# Altium schematic sheet lv_signal_air.SchDoc(lv_signal_air)
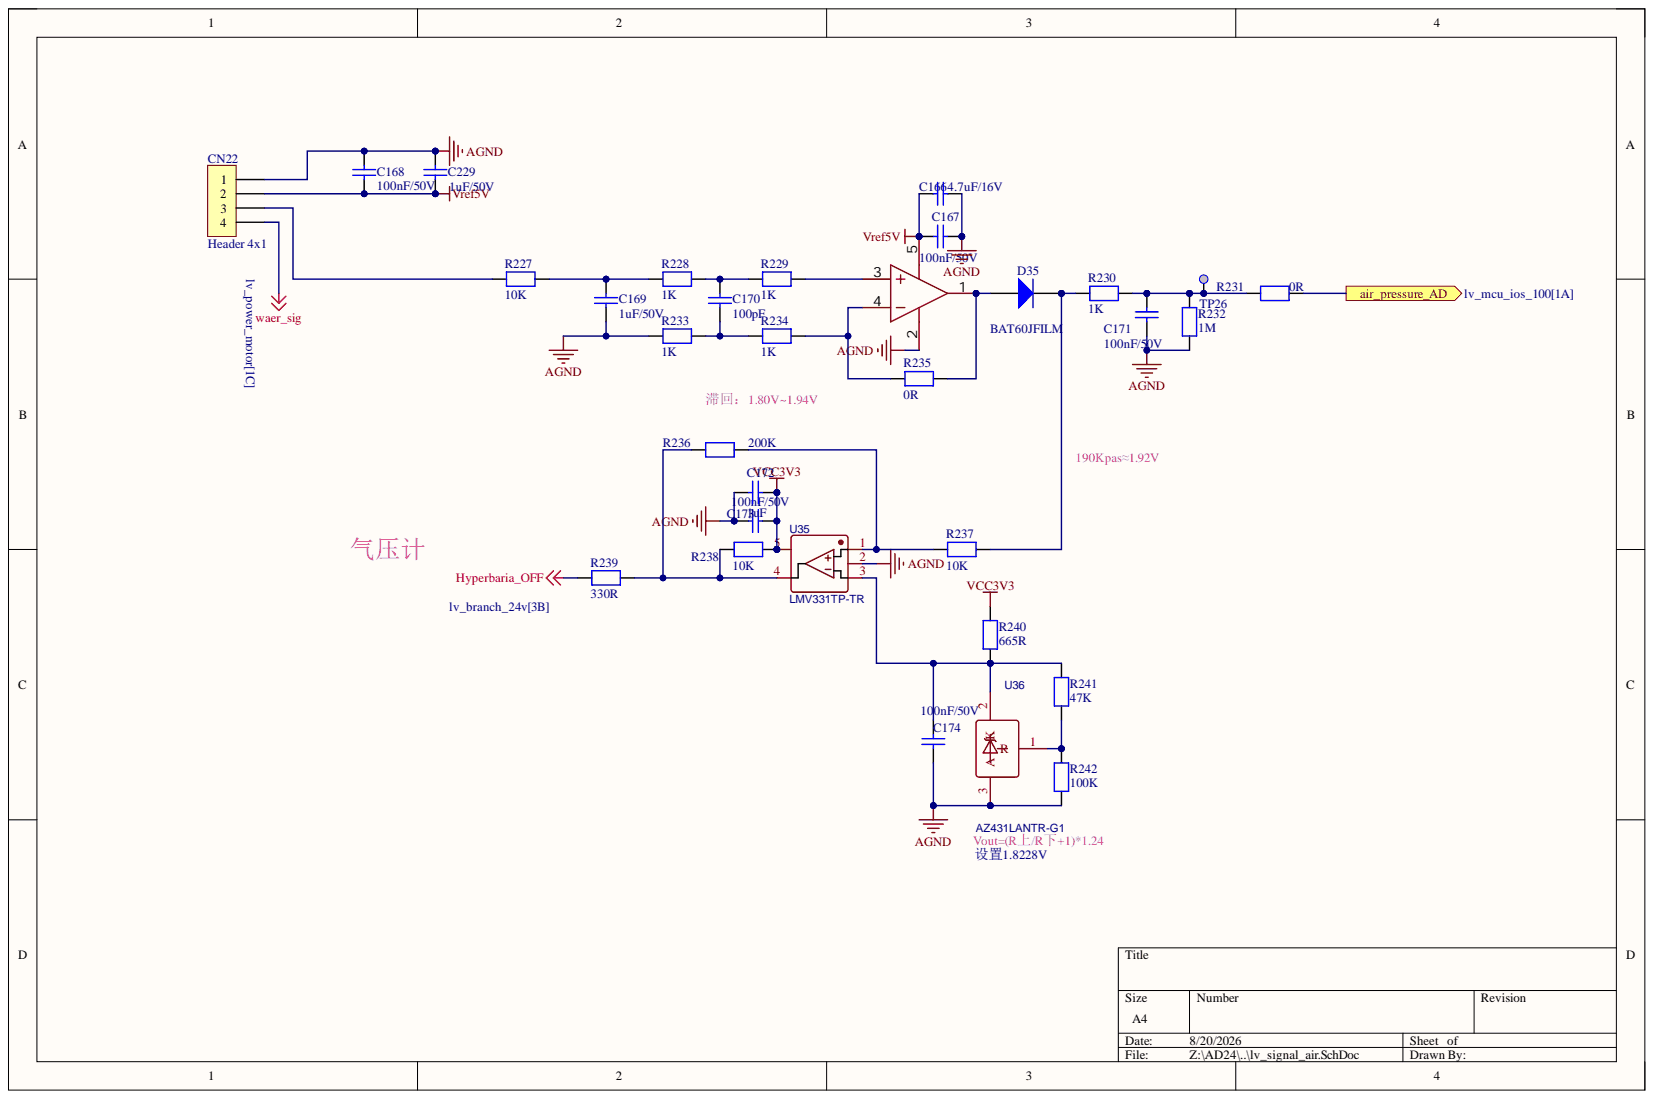
[diagram p0: lv_signal_air.SchDoc]

## Parts
C166  4.7uF/16V  [C0603]
C167  100nF/50V  [C0402]
C168  100nF/50V  [C0402]
C169  1uF/50V  [C0603]
C170  100pF  [C0402]
C171  100nF/50V  [C0402]
C172  value 1uF  [C0603]  (hidden comment: 1uF/50V)
C173  100nF/50V  [C0402]
C174  100nF/50V  [C0402]
C229  1uF/50V  [C0603]
CN22  Header 4x1  [CON_SAMTEC_TSW-104-07-G-S]
D35  BAT60JFILM  [DIO_SOD323]
R227  10K  [R0402]
R228  1K  [R0402]
R229  1K  [R0402]
R230  1K  [R0402]
R231  0R  [R0402]
R232  1M  [R0402]
R233  1K  [R0402]
R234  1K  [R0402]
R235  0R  [R0402]
R236  200K  [R0402]
R237  10K  [R0402]
R238  10K  [R0402]
R239  330R  [R0402]
R240  665R  [R0402]
R241  47K  [R0402]
R242  100K  [R0402]
TP26  AIRADC  [TP_SMD_1MM]  (value not drawn)  (no BOM)
U33  TLV271CDBVR  [SOT-23-5_L3.0-W1.7-P0.95-LS2.8-BR]  (value not drawn)
U35  LMV331TP-TR  [SOT-23-5_L3.0-W1.7-P0.95-LS2.8-BR]
U36  AZ431LANTR-G1  [SOT-23-3_L2.9-W1.6-P1.90-LS2.8-BR]

## Ports
air_pressure_AD

## Nets
AGND: C166.1 C167.2 C168.1 C169.2 C171.1 C172.1 C173.2 C174.2 C229.2 CN22.1 R232.1 R233.1 R242.1 U33.2 U35.2 U36.3
Hyperbaria_OFF: R239.1
VCC3V3: C172.2 C173.1 R238.2 R240.2 U35.5
Vref5V: C166.2 C167.1 C168.2 C229.1 CN22.2 U33.5
waer_sig: CN22.4
air_pressure_AD: R231.1 ; port air_pressure_AD
NetC169_1: C169.1 R227.2 R228.1
NetC170_1: C170.1 R228.2 R229.1
NetC170_2: C170.2 R233.2 R234.1
NetC171_2: C171.2 R230.2 R231.2 R232.2 TP26.1
NetC174_1: C174.1 R240.1 R241.2 U35.3 U36.2
NetCN22_3: CN22.3 R227.1
NetD35_1: D35.1 R235.1 U33.1
NetD35_2: D35.2 R230.1 R237.1
NetR229_2: R229.2 U33.3
NetR234_2: R234.2 R235.2 U33.4
NetR236_1: R236.1 R237.2 U35.1
NetR236_2: R236.2 R238.1 R239.2 U35.4
NetR241_1: R241.1 R242.2 U36.1

## Library data of the parts
C166 = Capacitor_1: 16V, X7S, 10%; Internal_Part_Number: MCD-00009; Manufacturer: muRata; Manufacturer_Part_Number: GRM188C71C475KE21D; Value: 4.7uF; Component Kind: Standard; ComponentLink1Description: Yageo_Capacitor_X5R-series.pdf; ComponentLink1URL: http://tunmcdsvn.tun.st.com/Component_Datasheet_Library/Trunk/Capacitors/Yageo_Multilayer_capacitor_X5R_series_100pF_to_100uF; Library Name: HiPin_Library_AD.IntLib; Package: C0603
C167 C168 C171 = Capacitor: 50V, 5%, X7R; Manufacturer: muRata; Manufacturer_Part_Number: GRM155R71H104KE14D; Component Kind: Standard; ComponentLink1Description: Datasheet; ComponentLink1URL: http://tunmcdsvn.tun.st.com/Component_Datasheet_Library/Trunk/Capacitors/Yageo_Capacitor_NP0_16V-to-50V; Library Name: HiPin_Library_AD.IntLib
C169 C229 = Capacitor_1: 50V, X5R, 10%; Manufacturer: muRata; Manufacturer_Part_Number: GRM188R61H105KAALD; Component Kind: Standard; ComponentLink1Description: Yageo_Capacitor_X5R-series.pdf; ComponentLink1URL: http://tunmcdsvn.tun.st.com/Component_Datasheet_Library/Trunk/Capacitors/Yageo_Multilayer_capacitor_X5R_series_100pF_to_100uF; Library Name: HiPin_Library_AD.IntLib
C170 = Capacitor: 50V, 5%, C0G; Manufacturer: muRata; Manufacturer_Part_Number: GRM1555C1H101JA01D; Component Kind: Standard; ComponentLink1Description: Datasheet; ComponentLink1URL: http://tunmcdsvn.tun.st.com/Component_Datasheet_Library/Trunk/Capacitors/Yageo_Capacitor_NP0_16V-to-50V; Library Name: HiPin_Library_AD.IntLib
C172 = MCD-00010: 50V, X5R, 10%; Manufacturer: YAGEO; Manufacturer_Part_Number: CC0603KRX5R7BB105; Part_Type: Capacitor; Database Table Name: MCD_PARTS$; Initial_Board_Reference: MB1274; Provided_By: Subcon; Component Kind: Standard; ComponentLink1Description: Yageo_Capacitor_X5R-series.pdf; ComponentLink1URL: http://tunmcdsvn.tun.st.com/Component_Datasheet_Library/Trunk/Capacitors/Yageo_Multilayer_capacitor_X5R_series_100pF_to_100uF; ECCN_EU: n/a; ECCN_US: n/a; Internal_Part_Number: MCD-00010; Library Name: MCD_PARTS_database.DbLib; Package: C0603; Status: Released
C173 C174 = Capacitor: 50V, 10%, X7R; Internal_Part_Number: MCD-00003; Manufacturer: muRata; Manufacturer_Part_Number: GRM155R71H104KE14D; Value: 100nF; Component Kind: Standard; ComponentLink1Description: Datasheet; ComponentLink1URL: http://tunmcdsvn.tun.st.com/Component_Datasheet_Library/Trunk/Capacitors/Yageo_Capacitor_NP0_16V-to-50V; Library Name: HiPin_Library_AD.IntLib; Package: C0402
CN22 = Header 4x1: Header, 4x1, 2,54mm, VR, TH, Height 5.84mm/2.54mm; Internal_Part_Number: MCD-00029; Manufacturer: SAMTEC; Manufacturer_Part_Number: TSW-104-07-x-S; Part_Type: Connector; Initial_Board_Reference: MB1274; Provided_By: Subcon; Component Kind: Standard; ComponentLink1Description: Samtec_connector_TSW-HTSW-series.pdf; ComponentLink1URL: http://tunmcdsvn.tun.st.com/Component_Datasheet_Library/Trunk/Connectors/Samtec_TSW-HTSW-series; ECCN_EU: n/a; ECCN_US: n/a; Library Name: HiPin_Library_AD.IntLib; Status: Released; Value: Header 4x1
D35 = BAT60JFILM: Internal_Part_Number: MCD-00034; Manufacturer: STMICROELECTRONICS; Part_Type: Diode; ECCN_EU: NEC; ECCN_US: EAR99; Initial_Board_Reference: MB1274; Provided_By: Subcon; Component Kind: Standard; ComponentLink1Description: STM_Diode_BAT60JFILM-SOD323.pdf; ComponentLink1URL: http://tunmcdsvn.tun.st.com/Component_Datasheet_Library/Trunk/Diodes/STM_Diode_BAT60JFILM-SOD323; Description: BAT60JFILM; Library Name: HiPin_Library_AD.IntLib; Manufacturer_Part_Number: BAT60JFILM; Status: Released; Value: BAT60JFILM
R227 R237 R238 = RES: 1%; Component Kind: Standard; Library Name: HiPin_Library_AD.IntLib; Value: 10K
R228-R236 R239 = RES: 1%; Value: 10K; Component Kind: Standard; Library Name: HiPin_Library_AD.IntLib
R240-R242 = RES: Resistor; Component Kind: Standard; Library Name: HiPin_Library_AD.IntLib
TP26 = MCD-00074: Test Point 1mm; Part_Type: Mechanical; Database Table Name: MCD_PARTS$; ComponentLink1Description: Datasheet; ComponentLink1URL: http://na; ECCN_EU: n/a; ECCN_US: n/a; Internal_Part_Number: MCD-00074; Library Name: MCD_PARTS_database.DbLib; Manufacturer: n/a; Manufacturer_Part_Number: n/a; Provided_By: n/a; Status: Released
U33 = TLV271CDBVR: 单电源运放; Manufacturer: TI(德州仪器); Add into BOM: yes; Current - Input Bias(Ib): 1pA; Gain Bandwidth Product(GBP): 3MHz; Number of Circuits: 单路; Output Current: 8mA; Slew Rate(SR): 2.6V/us; Supply Current: 625uA; Component Kind: Standard; Convert to PCB: yes; Datasheet: https://www.ti.com/cn/lit/gpn/tlv271; Device: TLV271CDBVR; JLCPCB Part Class: Extended Part; LCSC Part Name: TLV271CDBVR; Library Name: HiPin_Library_AD.IntLib; Manufacturer Part: TLV271CDBVR; Operating Temperature: 0℃~+70℃; Output Type: -; Rail to Rail: -; Supplier: LCSC; Supplier Footprint: SOT-23-5; Supplier Part: C131948; Symbol: TLV271CDBVR
U35 = LMV331TP-TR: 比较器; BOM_Manufacturer: 3PEAK; Contributor: LCSC; BOM_JLCPCB Part Class: Extended Part; BOM_Manufacturer Part: LMV331TP-TR; BOM_Supplier: LCSC; BOM_Supplier Part: C108095; Component Kind: Standard; Library Name: HiPin_Library_AD.IntLib; spicePre: U; spiceSymbolName: LMV331TP-TR
U36 = TL431: 参考电源; BOM_Manufacturer: JSMSEMI; Contributor: LCSC; BOM_JLCPCB Part Class: Extended Part; BOM_Manufacturer Part: TL431; BOM_Supplier: LCSC; BOM_Supplier Part: C963380; Component Kind: Standard; Library Name: HiPin_Library_AD.IntLib; spicePre: U; spiceSymbolName: TL431_C963380
